# Altium schematic sheet CAN and VE.Direct.SchDoc
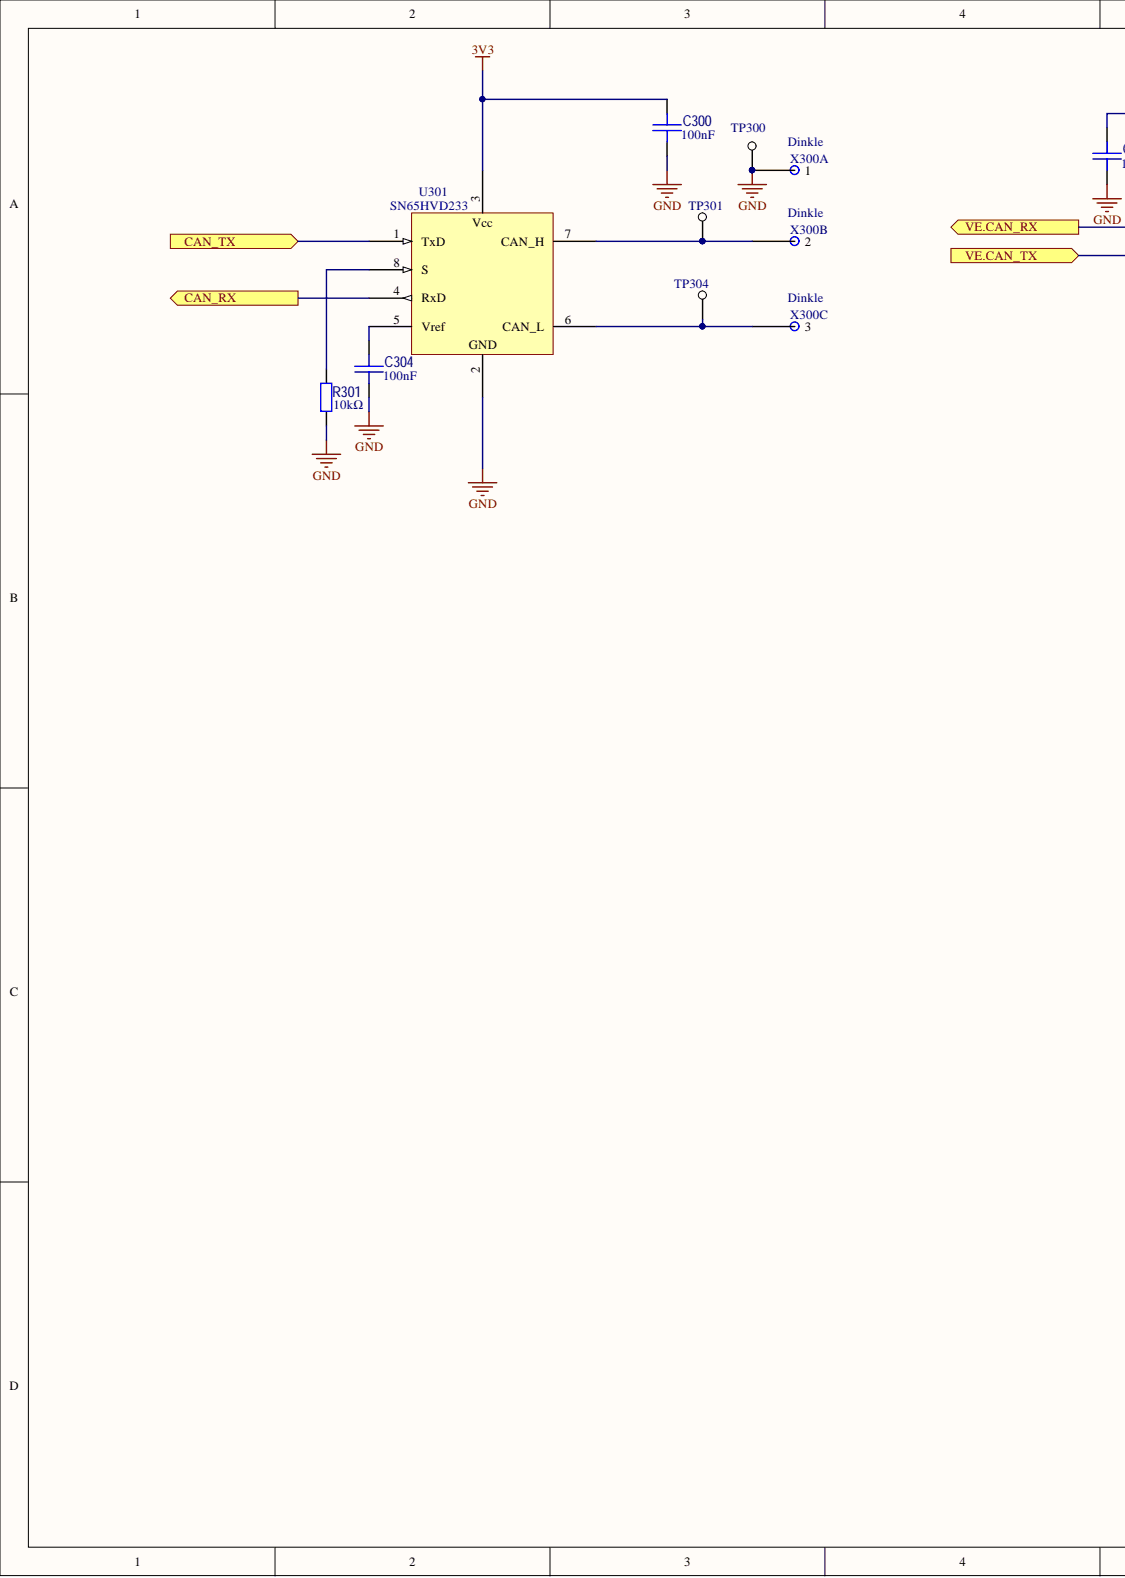
[diagram p0: CAN and VE.Direct.SchDoc, left]
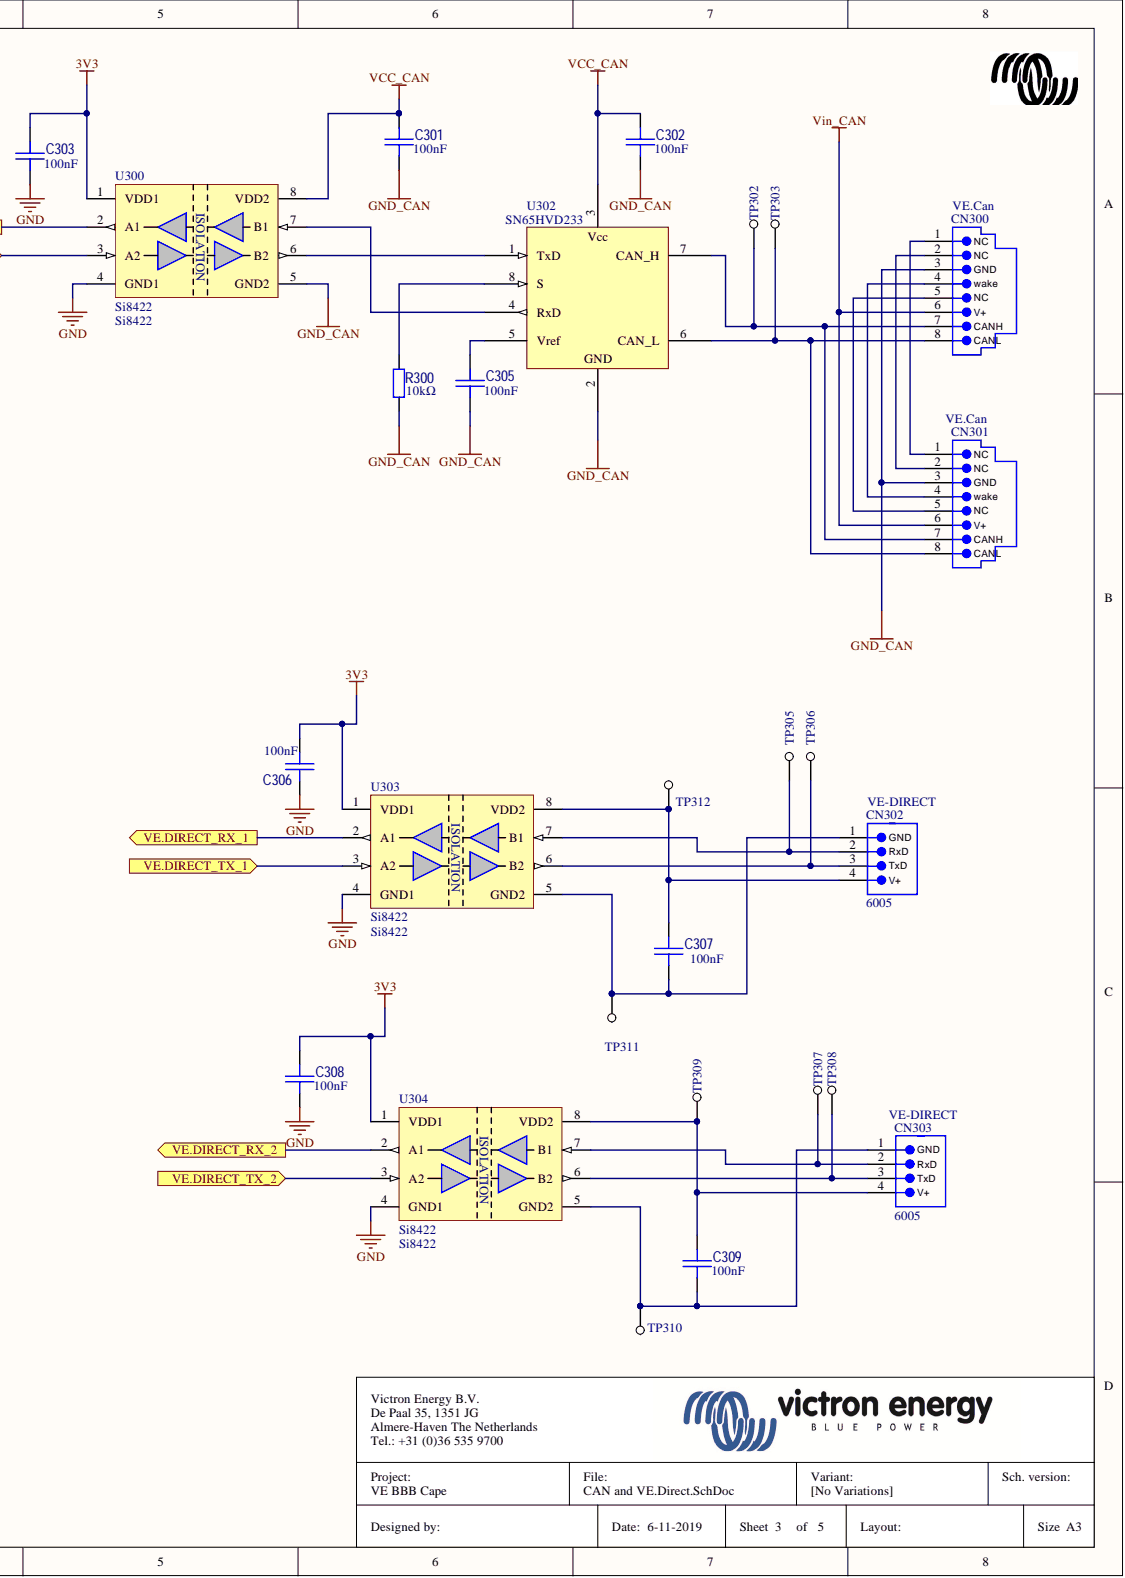
[diagram p1: CAN and VE.Direct.SchDoc, right]

## Parts
C300  value 100nF  [C0805_R]  (hidden comment: 1035)
C301  value 100nF  [C0805_R]  (hidden comment: 1035)
C302  value 100nF  [C0805_R]  (hidden comment: 1035)
C303  value 100nF  [C0805_R]  (hidden comment: 1035)
C304  value 100nF  [C0805_R]  (hidden comment: 1035)
C305  value 100nF  [C0805_R]  (hidden comment: 1035)
C306  value 100nF  [C0805_R]  (hidden comment: 1035)
C307  value 100nF  [C0805_R]  (hidden comment: 1035)
C308  value 100nF  [C0805_R]  (hidden comment: 1035)
C309  value 100nF  [C0805_R]  (hidden comment: 1035)
CN300  value VE.Can  [95001-2881]  (hidden comment: 100433)
CN301  value VE.Can  [95001-2881]  (hidden comment: 100433)
CN302  6005  value VE-DIRECT  [S4B-PH-K-S]
CN303  6005  value VE-DIRECT  [S4B-PH-K-S]
R300  value 10kΩ  [R0805_R]  (hidden comment: 396)
R301  value 10kΩ  [R0805_R]  (hidden comment: 396)
TP300  CN1  [testpad_round_1mm]  (value not drawn)  (no BOM)
TP301  CN1  [testpad_round_1mm]  (value not drawn)  (no BOM)
TP302  CN1  [testpad_round_1mm]  (value not drawn)  (no BOM)
TP303  CN1  [testpad_round_1mm]  (value not drawn)  (no BOM)
TP304  CN1  [testpad_round_1mm]  (value not drawn)  (no BOM)
TP305  CN1  [testpad_round_1mm]  (value not drawn)  (no BOM)
TP306  CN1  [testpad_round_1mm]  (value not drawn)  (no BOM)
TP307  CN1  [testpad_round_1mm]  (value not drawn)  (no BOM)
TP308  CN1  [testpad_round_1mm]  (value not drawn)  (no BOM)
TP309  CN1  [testpad_round_1mm]  (value not drawn)  (no BOM)
TP310  CN1  [testpad_round_1mm]  (value not drawn)  (no BOM)
TP311  CN1  [testpad_round_1mm]  (value not drawn)  (no BOM)
TP312  CN1  [testpad_round_1mm]  (value not drawn)  (no BOM)
U300  Si8422  [SO8_R]
U301  SN65HVD233  [SOIC8]
U302  SN65HVD233  [SOIC8]
U303  Si8422  [SO8_R]
U304  Si8422  [SO8_R]
X300  value Dinkle  MANUFACTURER: Dinkle  [ECH350R-3P]  (hidden comment: 3 pin terminal)  (parts A,B,C)

## Ports
CAN_RX, CAN_TX, VE.CAN_RX, VE.CAN_TX, VE.DIRECT_RX_1, VE.DIRECT_RX_2, VE.DIRECT_TX_1, VE.DIRECT_TX_2

## Nets
3V3: C300.1 C303.1 C306.1 C308.1 U300.1(VDD1) U301.3 U303.1(VDD1) U304.1(VDD1)
GND: C300.2 C303.2 C304.2 C306.2 C308.2 R301.2 TP300.1 U300.4(GND1) U301.2 U303.4(GND1) U304.4(GND1) X300.1
GND_CAN: C301.2 C302.2 C305.2 CN300.3(GND) CN301.3(GND) R300.2 U300.5(GND2) U302.2
VCC_CAN: C301.1 C302.1 U300.8(VDD2) U302.3
Vin_CAN: CN300.6(V+) CN301.6(V+)
CAN_RX: U301.4(RxD) ; port CAN_RX
CAN_TX: U301.1(TxD) ; port CAN_TX
VE.CAN_RX: U300.2(A1) ; port VE.CAN_RX
VE.CAN_TX: U300.3(A2) ; port VE.CAN_TX
VE.DIRECT_RX_1: U303.2(A1) ; port VE.DIRECT_RX_1
VE.DIRECT_RX_2: U304.2(A1) ; port VE.DIRECT_RX_2
VE.DIRECT_TX_1: U303.3(A2) ; port VE.DIRECT_TX_1
VE.DIRECT_TX_2: U304.3(A2) ; port VE.DIRECT_TX_2
NetC304_1: C304.1 U301.5(Vref)
NetC305_1: C305.1 U302.5(Vref)
NetC307_1: C307.1 CN302.4 TP312.1 U303.8(VDD2)
NetC307_2: C307.2 CN302.1 TP311.1 U303.5(GND2)
NetC309_1: C309.1 CN303.4(V+) TP309.1 U304.8(VDD2)
NetC309_2: C309.2 CN303.1(GND) TP310.1 U304.5(GND2)
NetCN300_1: CN300.1(NC) CN301.1(NC)
NetCN300_2: CN300.2(NC) CN301.2(NC)
NetCN300_4: CN300.4(wake) CN301.4(wake)
NetCN300_5: CN300.5(NC) CN301.5(NC)
NetCN300_7: CN300.7(CANH) CN301.7(CANH) TP302.1 U302.7(CAN_H)
NetCN300_8: CN300.8(CANL) CN301.8(CANL) TP303.1 U302.6(CAN_L)
NetCN302_2: CN302.2 TP305.1 U303.7(B1)
NetCN302_3: CN302.3 TP306.1 U303.6(B2)
NetCN303_2: CN303.2(RxD) TP307.1 U304.7(B1)
NetCN303_3: CN303.3(TxD) TP308.1 U304.6(B2)
NetR300_1: R300.1 U302.8(S)
NetR301_1: R301.1 U301.8(S)
NetTP301_1: TP301.1 U301.7(CAN_H) X300.2
NetTP304_1: TP304.1 U301.6(CAN_L) X300.3
NetU300_6: U300.6(B2) U302.1(TxD)
NetU300_7: U300.7(B1) U302.4(RxD)

## Library data of the parts
C300-C309 = 1035: Cap, SMD 0805, ceramic, X7R, 100nF, 50V, 10%, Yageo; INDIC_PARTNO: CSE100NF0805YAGY; MAN_PARTNUMBER: CC0805KPX7R9BB104; Database Table Name: AllComponents; INDIC_PRICE: 0,068; Shape: 805; Tol: 10,00%; Cls: CAP; Component Kind: Standard; MANUFACTURER: Yageo; Part: 1035; PartID: 1035; Supplier 1: Mouser; Supplier Part Number 1: 603-CC805KPX7R9BB104; Supplier Price 1: 0,005; Voltage: 50V; Library Name: VictronAltiumComponents.SVNDBLib
CN300 CN301 = 100433: VE.Can RJ45  connector; MAN_PARTNUMBER: 955012881; MANUFACTURER: Molex; Cls: CON; Database Table Name: AllComponents; INCAP_PRICE: 0,31; Component Kind: Standard; Part: 100433; PartID: 100433; Supplier 1: Arie; Supplier Part Number 1: WM5571-ND; Supplier Price 1: 0,22; Library Name: VictronAltiumComponents.SVNDBLib
CN302 CN303 = 6005: VE-DIRECT; MANUFACTURER: JST; Part_Description: VE-Direct connector, Shrouded; Cls: CON; Database Table Name: COMPDATA$; VENDOR: FArnell; VENDOR_PRICE: 0.07; VENDOR_STOCK_NR: 9492488; Component Kind: Standard; MAN_PARTNUMBER: S4B-PH-K-S; Part: 6005; Library Name: Data Base Librarys.DbLib
R300 R301 = 396: INCAP_PARTNO: 1035031290; INDIC_PARTNO: RSCE00010KFB7YAY; MAN_PARTNUMBER: RC0805FR-0710KL; MANUFACTURER: YAGEO; Part_Description: RES,MF,10K  0.125W 1%0805; Cls: RES; Database Table Name: COMPDATA$; INCAP_PRICE: 0.0014; INDIC_PRICE: 0.0018; Power: 0,125W; Shape: 805; Tol: 0.01; VENDOR: Mouser; VENDOR_PRICE: 0.003; VENDOR_STOCK_NR: 603-RC0805FR-0710KL; Component Kind: Standard; ComponentLink1Description: \datasheets\Yageo-RC0805FR-0710KL-datasheet.pdf; Part: 396; Library Name: Data Base Librarys.DbLib
TP300-TP312 = 100320: Testpad, Round, D=1mm; Cls: CON; Database Table Name: Connector; Part: 100320; PartID: 100320; Library Name: VictronAltiumComponents.SVNDBLib
U300 U303 U304 = 101550: IC, digital isolator, Si8422; MAN_PARTNUMBER: Si8422AB-D-IS; MANUFACTURER: Silabs; Database Table Name: AllComponents; Shape: SOIC8; Cls: IC; Component Kind: Standard; Part: 101550; PartID: 101550; Supplier 1: Digikey; Supplier Part Number 1: SI8422AB-D-ISR-ND; Supplier Price 1: 0,848; Value: Si8422; Library Name: VictronAltiumComponents.SVNDBLib
U301 U302 = 5006: MAN_PARTNUMBER: SN65HVD233DR; MANUFACTURER: TI; Part_Description: TI, SN65HVD1050, CAN Tranceiver 3V3; Cls: IC; Database Table Name: COMPDATA$; VENDOR: Digikey; VENDOR_PRICE: 1.25; VENDOR_STOCK_NR: 296-35967-1-ND; Component Kind: Standard; Part: 5006; Value: SN65HVD233; Library Name: Data Base Librarys.DbLib
X300 = 100410: Part_Description: 3 pin Pluggable terminal Dinkle ECH350R; Cls: CON; Database Table Name: COMPDATA$; VENDOR: ASTA TECHNOLOGIES; VENDOR_PRICE: 0.6399; Component Kind: Standard; MAN_PARTNUMBER: ECH350R-3P; Part: 100410; Library Name: Data Base Librarys.DbLib
